# Altium schematic sheet BTT TFT35-SPI V2.1.SchDoc
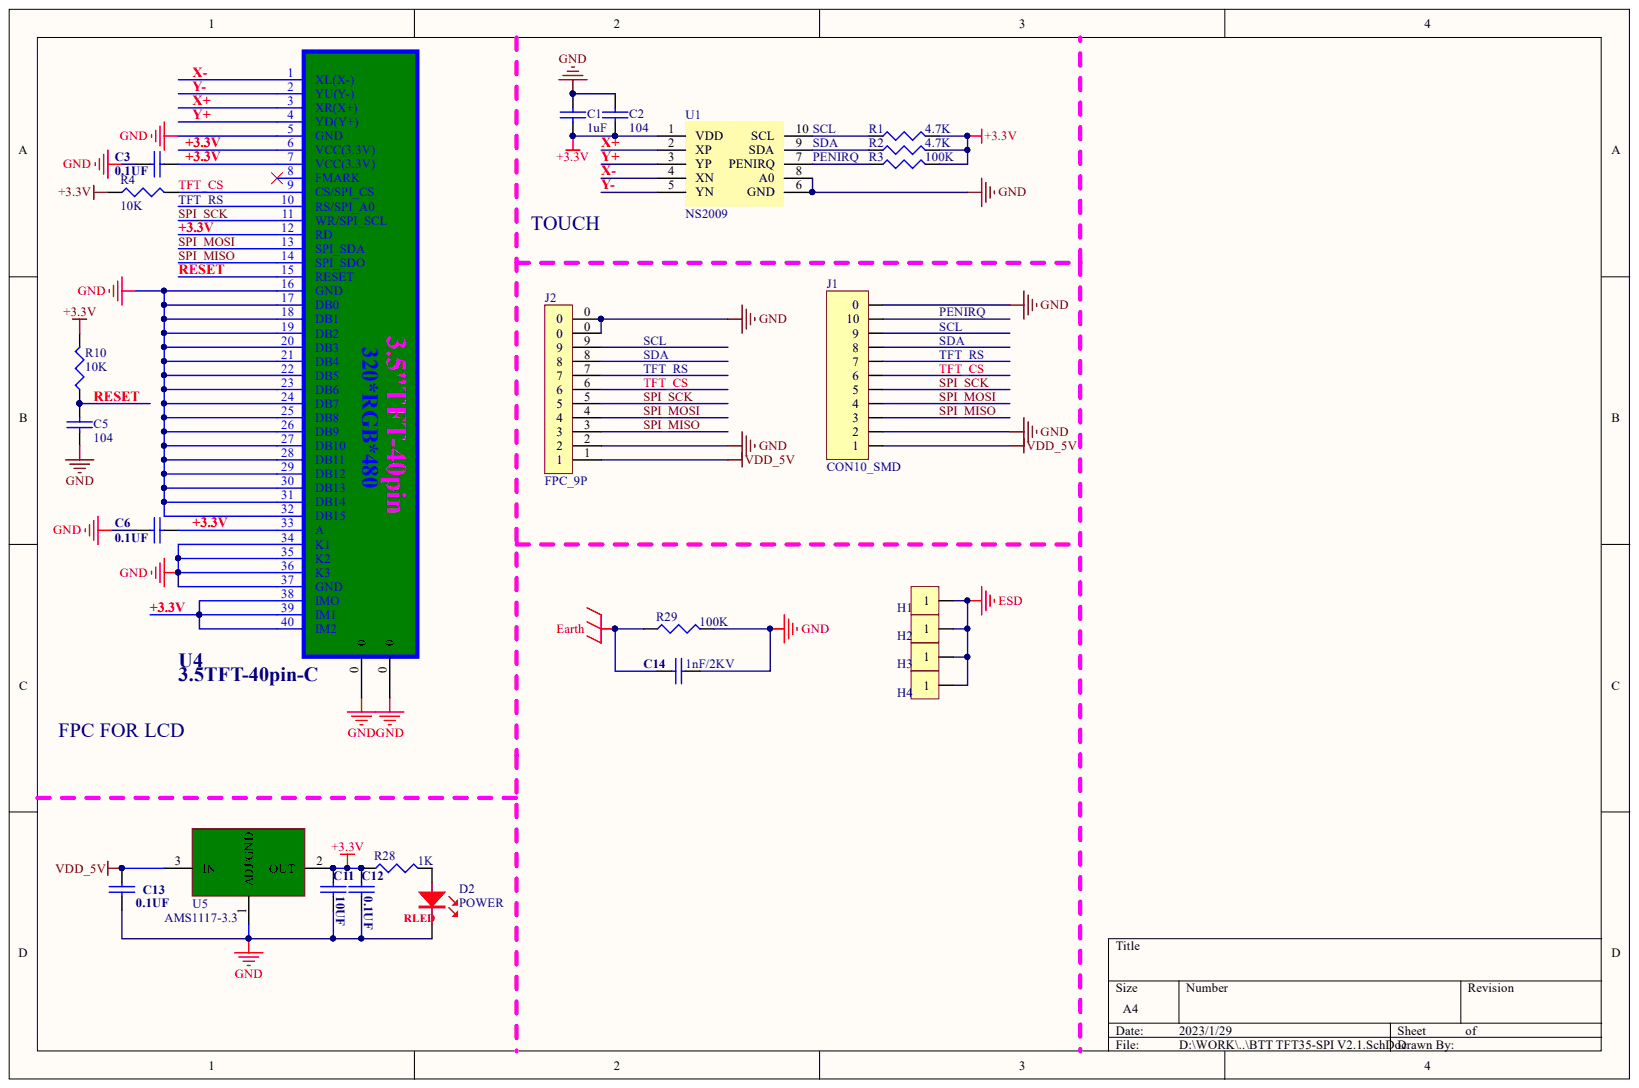
[diagram p0: BTT TFT35-SPI V2.1.SchDoc]

## Parts
C1  1uF  [0603C]
C2  104  [0603C]
C3  0.1UF  [0603-C]
C5  104  [0603C]
C6  0.1UF  [0603-C]
C11  10UF  [0805C]
C12  0.1UF  [0603-C]
C13  0.1UF  [0603-C]
C14  1nF/2KV  [1206C]
D2  LEDR  [0603D_RED]  (value not drawn)
H1  CON1  [PAD_3R2_5R]  (value not drawn)
H2  CON1  [PAD_3R2_5R]  (value not drawn)
H3  CON1  [PAD_3R2_5R]  (value not drawn)
H4  CON1  [PAD_3R2_5R]  (value not drawn)
J1  CON10_SMD  [XH2.54-LS-10P]  (value not drawn)
J2  FPC_9P  [FPC1.0-2H-WS-9P]
R1  4.7K  [0603R]
R2  4.7K  [0603R]
R3  100K  [0603R]
R4  10K  [0603R]
R10  10K  [0603R]
R28  1K  [0603R]
R29  100K  [1206R]
U1  NS2009  [MSOP10_3R3_3R3_P0R5]
U4  3.5TFT-40pin-C  [FPC0.5_WS_40P]
U5  AMS1117-3.3  [SOT-223]

## Nets
EARTH: C14.1 R29.1
ESD: H1.1 H2.1 H3.1 H4.1
GND: C1.1 C2.1 C3.1 C5.2 C6.1 C11.2 C12.2 C13.2 C14.2 D2.K J1.0 J1.2 J2.0 J2.2 R29.2 U1.6(GND) U1.8(A0) U4.0 U4.5(GND) U4.16(GND) U4.17(DB0) U4.18(DB1) U4.19(DB2) U4.20(DB3) U4.21(DB4) U4.22(DB5) U4.23(DB6) U4.24(DB7) U4.25(DB8) U4.26(DB9) U4.27(DB10) U4.28(DB11) U4.29(DB12) U4.30(DB13) U4.31(DB14) U4.32(DB15) U4.34(K1) U4.35(K2) U4.36(K3) U4.37(GND) U5.1
VDD_5V: C13.1 J1.1 J2.1 U5.3
+3.3V: C1.2 C2.2 C3.2 C6.2 C11.1 C12.1 R1.2 R2.2 R3.2 R4.1 R10.2 R28.1 U1.1(VDD) U4.6(VCC(3.3V)) U4.7(VCC(3.3V)) U4.12(RD) U4.33(A) U4.38(IMO) U4.39(IM1) U4.40(IM2) U5.2
PENIRQ: J1.10 R3.1 U1.7(PENIRQ)
RESET: C5.1 R10.1 U4.15(RESET)
SCL: J1.9 J2.9 R1.1 U1.10(SCL)
SDA: J1.8 J2.8 R2.1 U1.9(SDA)
SPI_MISO: J1.3 J2.3 U4.14(SPI_SDO)
SPI_MOSI: J1.4 J2.4 U4.13(SPI_SDA)
SPI_SCK: J1.5 J2.5 U4.11(WR/SPI_SCL)
TFT_CS: J1.6 J2.6 R4.2 U4.9(CS/SPI_CS)
TFT_RS: J1.7 J2.7 U4.10(RS/SPI_A0)
X+: U1.2(XP) U4.3(XR(X+))
X-: U1.4(XN) U4.1(XL(X-))
Y+: U1.3(YP) U4.4(YD(Y+))
Y-: U1.5(YN) U4.2(YU(Y-))
NetD2_A: D2.A R28.2

Not connected: U4 8(FMARK)

## Library data of the parts
C1 C2 C5 = CAP: Capacitor; Component Kind: Standard; Library Name: My Useful Discrete Devices.SchLib
C3 C6 C11-C14 = CAP: Component Kind: Standard; Library Name: liroqi.SchLib
H1-H4 = CON1: Connector; Component Kind: Standard; Library Name: My Useful Discrete Devices.SchLib
J1 = CON10_SMD: Connector; Component Kind: Standard; Library Name: My Useful Discrete Devices.SchLib
J2 = FPC-9P: Component Kind: Standard; Library Name: My Useful Discrete Devices.SchLib
R1-R4 R10 R28 R29 = RES1: Component Kind: Standard; Library Name: My Useful Discrete Devices.SchLib
U1 = NS2009: 电阻式触摸屏采集芯片; Component Kind: Standard; Library Name: My Useful IC.SchLib
U5 = LM1084: Component Kind: Standard; Library Name: liroqi-2.SchLib
D2 = LEDR: : POWER; Component Kind: Standard; Library Name: My Useful Discrete Devices.SchLib
U4 = 3.5TFT-40pin-C: Component Kind: Standard; Library Name: liroqi.SchLib
